# Altium schematic sheet dcdc.SchDoc
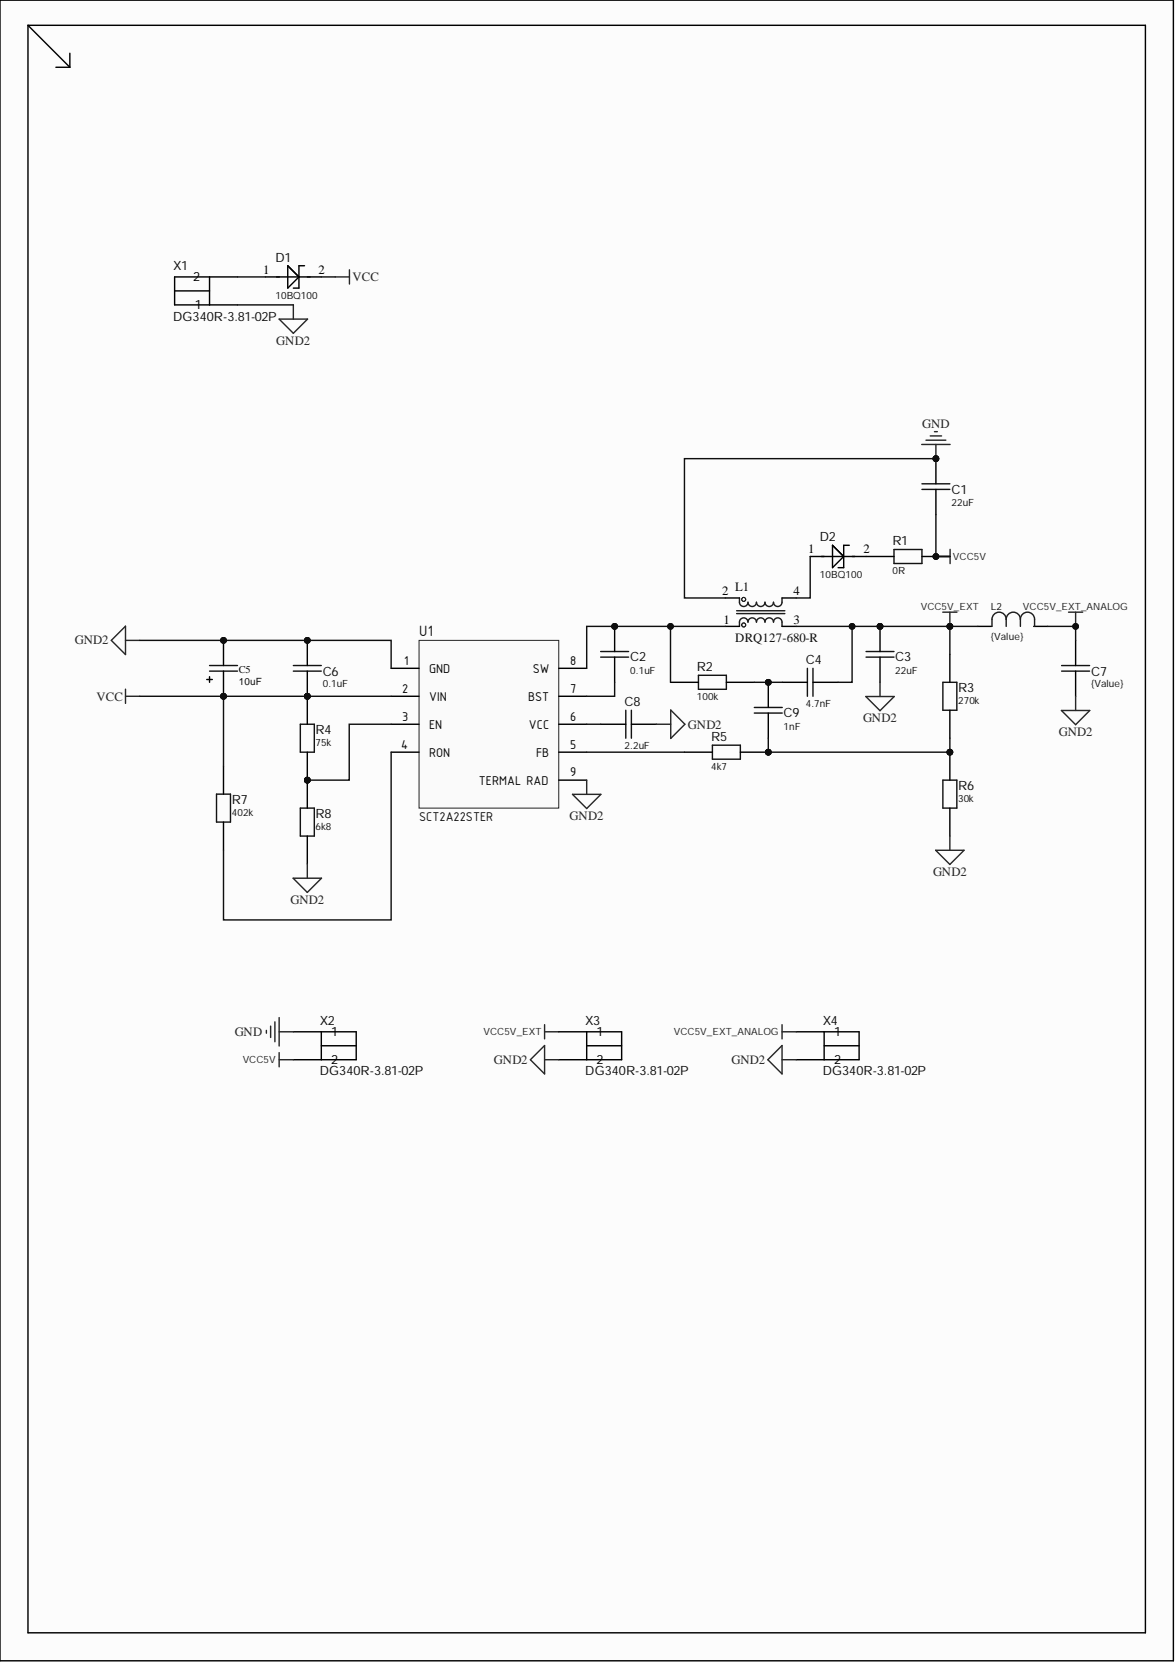
[diagram p0: dcdc.SchDoc]

## Parts
C1  22uF  [C_0603]
C2  0.1uF  [C_0603]
C3  22uF  [C_0603]
C4  4.7nF  [C_0603]
C5  10uF  [CAP470X25V]
C6  0.1uF  [C_1206]
C7  {Value}  [C_0603]
C8  2.2uF  [C_0603]
C9  1nF  [C_0603]
D1  10BQ100  [DO-214AA]
D2  10BQ100  [DO-214AA]
L1  DRQ127-680-R  [XFMR_DRQ127-150-R]
L2  {Value}  [CD54NP]
R1  0R  [R_0603]
R2  100k  [R_0603]
R3  270k  [R_0603]
R4  value 75k  [R_0603]  (hidden comment: 30k)
R5  value 4k7  [R_0603]  (hidden comment: 5k1)
R6  value 30k  [R_0603]  (hidden comment: 20k)
R7  value 402k  [R_0603]  (hidden comment: 165k)
R8  6k8  [R_0603]
U1  SCT2A22STER  [ESOP-8]
X1  DG340R-3.81-02P  [340-021-12]
X2  DG340R-3.81-02P  [340-021-12]
X3  DG340R-3.81-02P  [340-021-12]
X4  DG340R-3.81-02P  [340-021-12]

## Nets
GND: C1.2 L1.2 X2.1
GND2: C3.1 C5.1 C6.2 C7.1 C8.1 R6.1 R8.1 U1.1(GND) U1.9(RAD) X1.1 X3.2 X4.2
VCC: C5.2 C6.1 D1.2 R4.2 R7.2 U1.2(VIN)
VCC5V: C1.1 R1.1 X2.2
VCC5V_EXT: C3.2 C4.2 L1.3 L2.2 R3.2 X3.1
VCC5V_EXT_ANALOG: C7.2 L2.1 X4.1
NetC2_1: C2.1 U1.7(BST)
NetC2_2: C2.2 L1.1 R2.2 U1.8(SW)
NetC4_1: C4.1 C9.1 R2.1
NetC8_2: C8.2 U1.6(VCC)
NetC9_2: C9.2 R3.1 R5.2 R6.2
NetD1_1: D1.1 X1.2
NetD2_1: D2.1 L1.4
NetD2_2: D2.2 R1.2
NetR4_1: R4.1 R8.2 U1.3(EN)
NetR5_1: R5.1 U1.5(FB)
NetR7_1: R7.1 U1.4(RON)

## Library data of the parts
C1 C3 = C0603: Capacitor; Alternative Part: CC0603MRX6S5BB226; Part Number: CC0603MRX5R6BB226; Component Kind: Standard; Library Name: capacitors.schlib; Value: 22uF
C2 = C0603: Capacitor; Alternative Part: CC0603MRY5V9BB104; Part Number: CC0603KRX7R9BB104; Component Kind: Standard; Library Name: capacitors.schlib; Value: 0.1uF
C4 = C0603: Capacitor; Component Kind: Standard; Library Name: capacitors.schlib; Value: 4.7nF
C5 = C_10_12_5mm: Capacitor; Alternative Part: no; Part Number: SH160M0010B5S-1012; Component Kind: Standard; Library Name: capacitors.schlib; Value: 10uF
C6 = C1206: Capacitor; Alternative Part: CC1206JKX7R0BB104; Part Number: CC1206KKX7R0BB104; Component Kind: Standard; Library Name: capacitors.schlib; Value: 0.1uF
C7 = C0603: Capacitor; Alternative Part: no connection; Component Kind: Standard; Library Name: capacitors.schlib; Part Number: no connection; Value: {Value}
C8 = C0603: Capacitor; Alternative Part: no; Part Number: CC0603KRX5R8BB225; Component Kind: Standard; Library Name: capacitors.schlib; Value: 2.2uF
C9 = C0603: Capacitor; Alternative Part: CC0603KRX7R8BB102; Part Number: CC0603KRX7R9BB102; Component Kind: Standard; Library Name: capacitors.schlib; Value: 1nF
D1 D2 = D_DO-214AA: Diode; Alternative Part: VS-10BQ100; Component Kind: Standard; Library Name: diode.schlib; Part Number: 10BQ100
L1 = DRQ127-150-R: Inductor Power Shielded; Alternative Part: no; Availability: Unavailable; Component Kind: Standard; Library Name: inductors_transformers.schlib; Package: None; Part Number: DRQ127-680-R; Price: None
L2 = L_CD54NP: Inductor; Alternative Part: no connection; Component Kind: Standard; Library Name: inductors_transformers.schlib; Part Number: no connection; Value: {Value}
R1 = R0603: Resistor; Alternative Part: ?; Component Kind: Standard; Library Name: resistors.schlib; Part Number: ?; Value: 0R
R2 = R0603: Resistor; Alternative Part: RC0603JR-07100KL; Part Number: RC0603FR-07100KL; Component Kind: Standard; Library Name: resistors.schlib; Value: 100k
R3 = R0603: Resistor; Alternative Part: RC0603JR-07270KL; Part Number: RC0603FR-07270KL; Component Kind: Standard; Library Name: resistors.schlib; Value: 270k
R4 = R0603: Resistor; Alternative Part: RC0603FR-0775KL; Part Number: RC0603JR-0775KL; Component Kind: Standard; Library Name: resistors.schlib
R5 = R0603: Resistor; Alternative Part: RC0603JR-074K7L; Part Number: RC0603FR-074K7L; Component Kind: Standard; Library Name: resistors.schlib
R6 = R0603: Resistor; Alternative Part: RC0603JR-0730KL; Part Number: RC0603FR-0730KL; Component Kind: Standard; Library Name: resistors.schlib
R7 = R0603: Resistor; Alternative Part: RC0603FR-13402KL; Part Number: RC0603FR-07402KL; Component Kind: Standard; Library Name: resistors.schlib
R8 = R0603: Resistor; Alternative Part: RC0603JR-076K8L; Part Number: RC0603FR-076K8L; Component Kind: Standard; Library Name: resistors.schlib; Value: 6k8
U1 = SCT2A22STER: Buck dc/dc; Alternative Part: no; Component Kind: Standard; Library Name: dcdc_ldo_ref.schlib; Part Number: SCT2A22STER
X1-X4 = DG340R-3.81-02P: Connectors; Alternative Part: KLS2-340-3.81-02P-2S; Component Kind: Standard; Part Number: DG340R-3.81-02P; Type: DG340R-3.81-02P; Library Name: connectors_socets.schlib
